# Altium schematic sheet Mesh_Periph.SchDoc(Mesh_Periph)
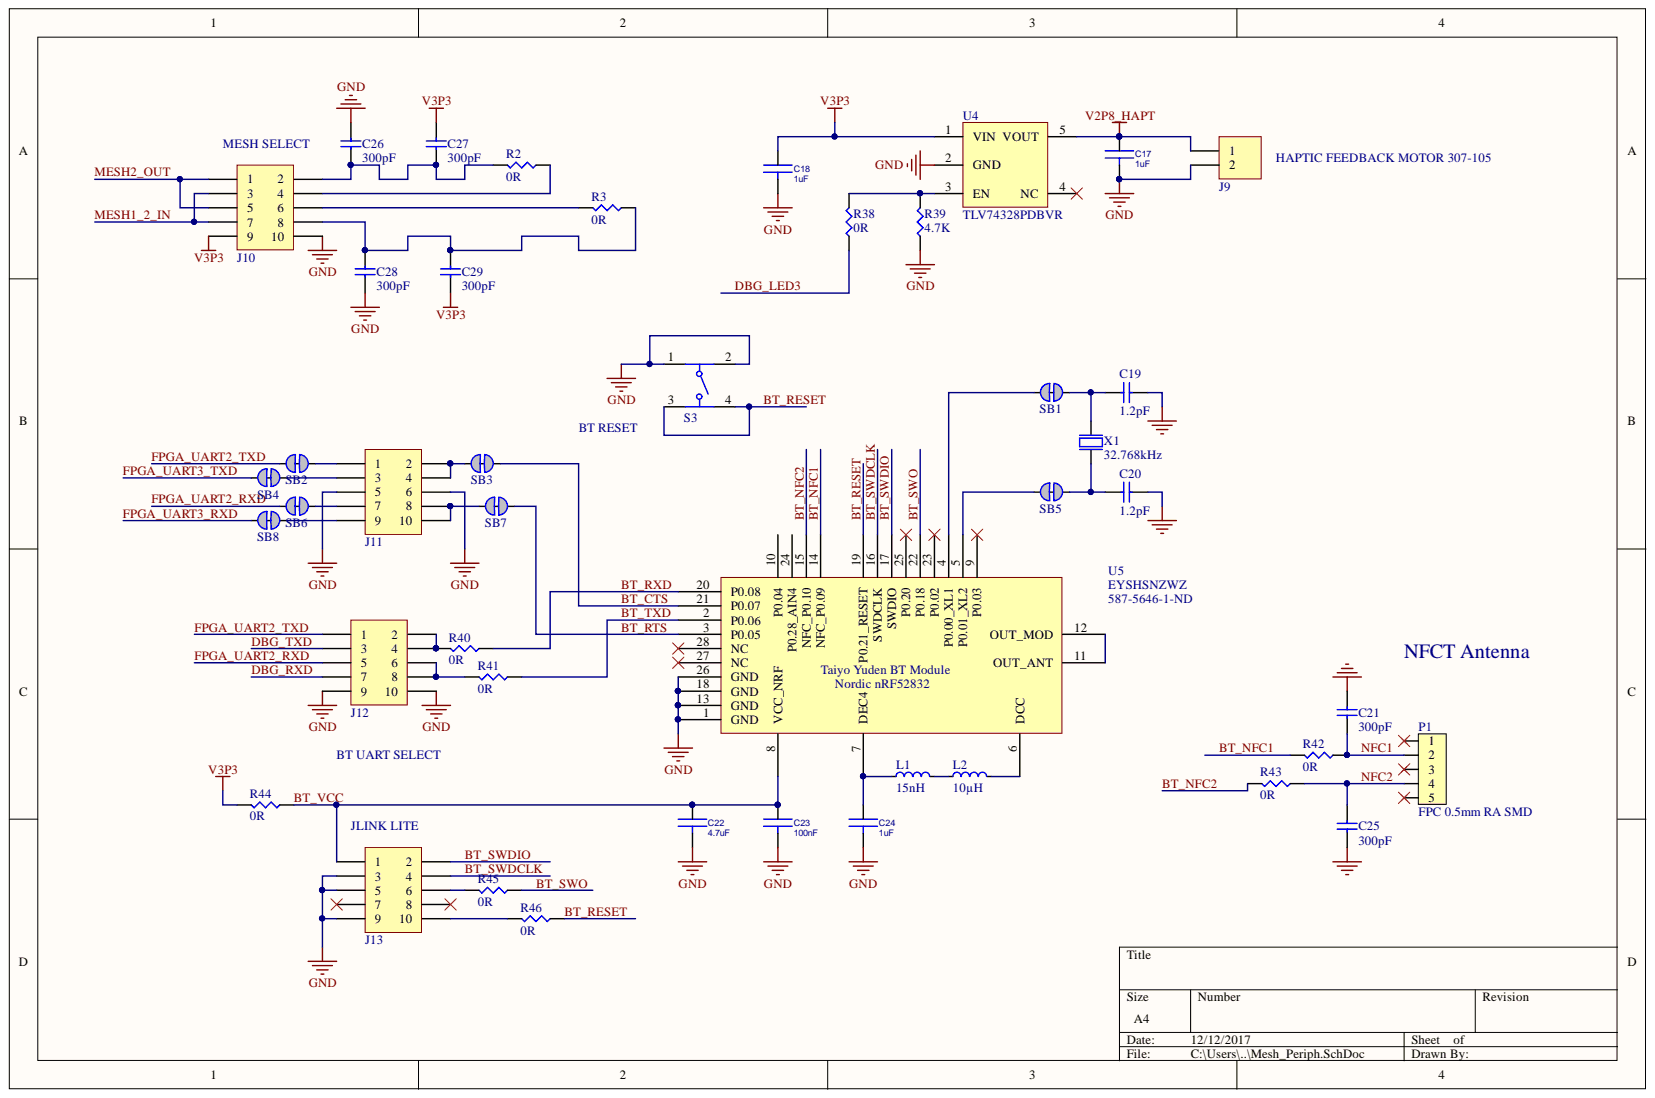
[diagram p0: Mesh_Periph.SchDoc]

## Parts
C17  1uF  [0603C]
C18  1uF  [0603C]
C19  1.2pF  [CAPC1005X04L]
C20  1.2pF  [CAPC1005X04L]
C21  300pF  [CAPC1608X06L]
C22  4.7uF  [0603C]
C23  100nF  [0603C]
C24  1uF  [0603C]
C25  300pF  [CAPC1608X06L]
C26  300pF  [CAPC1608X06L]
C27  300pF  [CAPC1608X06L]
C28  300pF  [CAPC1608X06L]
C29  300pF  [CAPC1608X06L]
J9  61300211121  [61300211121]  (value not drawn)
J10  61301021121  [61301021121]  (value not drawn)
J11  61301021121  [61301021121]  (value not drawn)
J12  61301021121  [61301021121]  (value not drawn)
J13  62201021121  [62201021121]  (value not drawn)
L1  15nH  [INDC1005X04L]
L2  10µH  [INDC1608X06L]
P1  FPC 0.5mm RA SMD  [FPC_05P-0.5mm-RA-SMD]
R2  0R  [R0603]
R3  0R  [R0603]
R38  0R  [R0603]
R39  4.7K  [R0603]
R40  0R  [R0603]
R41  0R  [R0603]
R42  0R  [R0603]
R43  0R  [R0603]
R44  0R  [R0603]
R45  0R  [R0603]
R46  0R  [R0603]
S3  430773034825  [4307x30348xx_6x6x3.4]  (value not drawn)
SB1  Solderbridge  [Solderbridge_Shorted]  (value not drawn)
SB2  Solderbridge  [Solderbridge_Shorted]  (value not drawn)
SB3  Solderbridge  [Solderbridge_Shorted]  (value not drawn)
SB4  Solderbridge  [Solderbridge_Shorted]  (value not drawn)
SB5  Solderbridge  [Solderbridge_Shorted]  (value not drawn)
SB6  Solderbridge  [Solderbridge_Shorted]  (value not drawn)
SB7  Solderbridge  [Solderbridge_Shorted]  (value not drawn)
SB8  Solderbridge  [Solderbridge_Shorted]  (value not drawn)
U4  TLV74328PDBVR  [SOT23-5]
U5  EYSHSNZWZ  Supplier Part Number 1: 587-5646-1-ND  [EYSHSNZWZ]
X1  32.768kHz  [XTAL_3215]

## Nets
GND: C17.2 C18.2 C19.1 C20.1 C21.1 C22.2 C23.2 C24.2 C25.1 C26.2 C28.1 J9.2 J10.10 J11.5 J11.6 J12.9 J12.10 J13.3 J13.5 J13.9 R39.1 S3.1 S3.2 U4.2(GND) U5.1(GND) U5.13(GND) U5.18(GND) U5.26(GND)
V2P8_HAPT: C17.1 J9.1 U4.5(VOUT)
V3P3: C18.1 C27.2 C29.1 J10.9 R44.2 U4.1(VIN)
BT_CTS: SB3.1 U5.21(P0.07)
BT_NFC1: R42.2 U5.14(NFC_P0.09)
BT_NFC2: R43.2 U5.15(NFC_P0.10)
BT_RESET: R46.1 S3.3 S3.4 U5.19(P0.21_RESET)
BT_RTS: SB7.1 U5.3(P0.05)
BT_RXD: R40.1 U5.20(P0.08)
BT_SWDCLK: J13.4 U5.16(SWDCLK)
BT_SWDIO: J13.2 U5.17(SWDIO)
BT_SWO: R45.1 U5.22(P0.18)
BT_TXD: R41.1 U5.2(P0.06)
BT_VCC: C22.1 C23.1 J13.1 R44.1 U5.8(VCC_NRF)
DBG_LED3: R38.1
DBG_RXD: J12.7
DBG_TXD: J12.3
FPGA_UART2_RXD: J12.5 SB6.2
FPGA_UART2_TXD: J12.1 SB2.2
FPGA_UART3_RXD: SB8.2
FPGA_UART3_TXD: SB4.2
MESH1_2_IN: J10.3 J10.7
MESH2_OUT: J10.1 J10.5
NFC1: C21.2 P1.2 R42.1
NFC2: C25.2 P1.4 R43.1
NetC19_2: C19.2 SB1.1 X1.1
NetC20_2: C20.2 SB5.1 X1.2
NetC24_1: C24.1 L1.1 U5.7(DEC4)
NetC26_1: C26.1 C27.1 J10.2 R2.2
NetC28_2: C28.2 C29.2 J10.8 R3.1
NetJ10_4: J10.4 R2.1
NetJ10_6: J10.6 R3.2
NetJ11_1: J11.1 SB2.1
NetJ11_2: J11.2 J11.4 SB3.2
NetJ11_3: J11.3 SB4.1
NetJ11_7: J11.7 SB6.1
NetJ11_8: J11.8 J11.10 SB7.2
NetJ11_9: J11.9 SB8.1
NetJ12_2: J12.2 J12.4 R40.2
NetJ12_6: J12.6 J12.8 R41.2
NetJ13_6: J13.6 R45.2
NetJ13_10: J13.10 R46.2
NetL1_2: L1.2 L2.1
NetL2_2: L2.2 U5.6(DCC)
NetR38_2: R38.2 R39.2 U4.3(EN)
NetSB1_2: SB1.2 U5.4(P0.00_XL1)
NetSB5_2: SB5.2 U5.5(P0.01_XL2)
NetU5_11: U5.11(OUT_ANT) U5.12(OUT_MOD)

Not connected: J13 7 8; P1 1 3 5; U4 4(NC); U5 9(P0.03) 10(P0.04) 23(P0.02) 24(P0.28_AIN4) 25(P0.20) 27(NC) 28(NC)

## Library data of the parts
C17 C18 C22 C24 = 1uF/0603/16V: Description 1: CAP TANT 1UF 16V 10% 0603; Supplier Part Number 1: 478-4184-2-ND; Supplier 1: Digi-Key; Library Name: Control_Board.SchLib; Component Kind: Standard
C19 C20 = CAP_0402: Capacitor, NP0, ±0.1pF; Part Number: C0402-1.2p; Note: 50V; Artikkelnr Simpro: N.A.; Artikkelnr Noca: 20029157; Value: 1.2pF; Published: 2009-05-08; Pin Count: 2; Library Name: pca10040.IntLib; LatestRevisionDate: 2011-05-11; Footprint Doc: 0402; Component Kind: Standard; Publisher: Nordic Semiconductor
C21 C25-C29 = CAP_0603: Capacitor, X5R, ±10%; Part Number: C0603-4µ7; Value: 4.7µF; Note: 6.3V; Artikkelnr Simpro: N.A.; Artikkelnr Noca: 20069060; Published: 2009-05-08; Pin Count: 2; Library Name: pca10040.IntLib; LatestRevisionDate: 2009-11-23; Footprint Doc: 0603; Component Kind: Standard; Publisher: Nordic Semiconductor
C23 = 100nF/0603: Description 1: CAP CER 0.1UF 25V 10% X7R 0603; Supplier Part Number 1: 311-1341-6-ND; Supplier 1: Digi-Key; Library Name: Control_Board.SchLib; Component Kind: Standard
J9 = 61300211121: WR-PHD 2.54 mm THT Pin Header, 2p; Working Voltage: 250 VAC; Withstanding Voltage: 500 VAC; Rated Current: 3 A; Pitch: 2.54 mm; Pin Number: 2; Published Date: Aug 2017; Part Number: 61300211121; Library Name: Altium_WR-PHD_PIN_HEADER_2_54 (rev17a).IntLib; ComponentLink2URL: http://katalog.we-online.de/em/datasheet/6130xx11121.pdf; ComponentLink2Description: Datasheet; ComponentLink1URL: http://www.we-online.de; ComponentLink1Description: Manufacturer; Component Kind: Standard; Publisher: Wurth Elektronik
J10-J12 = 61301021121: WR-PHD 2.54 mm THT Dual Pin Header, 10p; Working Voltage: 250 VAC; Withstanding Voltage: 500 VAC; Rated Current: 3 A; Pitch: 2.54 mm; Pin Number: 10; Published Date: Aug 2017; Part Number: 61301021121; Library Name: Altium_WR-PHD_PIN_HEADER_2_54 (rev17a).IntLib; ComponentLink2URL: http://katalog.we-online.de/em/datasheet/6130xx21121.pdf; ComponentLink2Description: Datasheet; ComponentLink1URL: http://www.we-online.de; ComponentLink1Description: Manufacturer; Component Kind: Standard; Publisher: Wurth Elektronik
J13 = 62201021121: WR-PHD 1.27 mm THT Dual Pin Header, 10p; Working Voltage: 100 VAC; Withstanding Voltage: 500 VAC; Rated Current: 1 A; Pitch: 1.27 mm; Pin Number: 10; Published Date: Aug 2017; Part Number: 62201021121; Library Name: 6220xx21121 (rev17b).IntLib; ComponentLink2URL: http://katalog.we-online.de/em/datasheet/62201021121.pdf; ComponentLink2Description: Datasheet; ComponentLink1URL: http://www.we-online.de; ComponentLink1Description: Manufacturer; Component Kind: Standard; Publisher: Wurth Elektronik
L1 = L0402-15n: Inductor, 320mA, ±5%; Manufacturer Part Number: HK100515NJ-T; Manufacturer: Taiyo Yuden; 2nd source part number: 658-1328; Note: High frequency chip inductor ±10%; Artikkelnr Simpro: N.A.; Artikkelnr Noca: 33029323; Value: 15nH; Supplier part number: 587-1521-1-ND; Supplier: Digi-Key; Published: 2010-07-12; Pin Count: 2; Part Number: L0402-15n; Library Name: pca10040.IntLib; LatestRevisionDate: 2012-09-19; Footprint Doc: 0402; Datasheet: http://www.yuden.co.jp/productdata/catalog/en/mlci04_e.pdf; Component Kind: Standard; 2nd source Supplier: Farnell; Publisher: Nordic Semiconductor
L2 = L0603-10µ: Inductor, 80mA, ±10%; Manufacturer Part Number: LBMF1608T100K; Manufacturer: Taiyo Yuden; 2nd source part number: 178-6105; Note: LBMF1608T100K 10µH 80mA 0603; Artikkelnr Simpro: N.A.; Artikkelnr Noca: 33029314; Value: 10µH; Supplier part number: 587-1714-1-ND; Supplier: Digi-Key; Published: 2010-02-24; Pin Count: 2; Part Number: L0603-10µ; Library Name: pca10040.IntLib; LatestRevisionDate: 2012-09-19; Footprint Doc: 0603; Datasheet: http://www.yuden.co.jp/ut/product/pdf/elb2_e.pdf; Component Kind: Standard; 2nd source Supplier: Farnell; Publisher: Nordic Semiconductor
P1 = FPC_05P-0.5mm: 0.5mm FPC, 5P,Right Angle SMT,Double Contact,H=1.2mm,White, Tin plated; Manufacturer Part Number: 5141-05RZDNWR01; Manufacturer: W-CON; 2nd source part number: WM9836CT-ND; Artikkelnr Simpro: N.A.; Artikkelnr Noca: 55010020; Value: FPC 0.5mm RA SMD; Supplier part number: 5141-05RZDNWR01; Supplier: Cabcon; Published: 2015-06-22; Pin Count: 7; Part Number: FPC_05P-0.5mm-RA-SMD; Library Name: pca10040.IntLib; LatestRevisionDate: 2016-04-21; Footprint Doc: FPC_05P-0.5mm-RA-SMD; Datasheet: \\hizz\pro\app9717\Datasheet\connectores\W-CON\5141-XXRZDNXX0X.pdf; Component Kind: Standard; 2nd source Supplier: Digi-Key; Publisher: Nordic Semiconductor
R2 R3 R38-R46 = 1K/1%/0603: Description 1: Thick Film Resistors - SMD 1K OHM 1%; Supplier Part Number 1: 311-1.00KHRCT-ND; Supplier 1: Digi-Key; Library Name: Control_Board.SchLib; Component Kind: Standard
S3 = 430773034825: WS-TASV 6x6mm J-Hook Hermetic Tact Switch, SMD version; Operation Force: 250g; Size: 6x6x3.4 mm; Published Date: June 2017; Part Number: 430773034825; Life Cycle: 500000 cycles; Library Name: Altium_WS-TASV (rev17a).IntLib; ComponentLink2URL: http://katalog.we-online.de/em/datasheet/430773034825.pdf; ComponentLink2Description: Datasheet; ComponentLink1URL: http://www.we-online.de; ComponentLink1Description: Manufacturer; Component Kind: Standard; Publisher: Wurth Elektronik
SB1-SB8 = SOLDERBRIDGE_SHORTED: Shorted Solderbridge; Part Number: Solderbridge Shorted; Artikkelnr Simpro: N.A.; Value: Solderbridge; Published: 2012-08-07; Pin Count: 2; Library Name: pca10040.IntLib; LatestRevisionDate: 2012-08-07; Footprint Doc: Solderbridge_Shorted; Component Kind: Net Tie; Artikkelnr Noca: N.A.; Publisher: Nordic Semiconductor
U4 = RT9198-28PBR: Descritpion 1: 300mA, Low Noise, Ultra-Fast CMOS LDO REG, 2.8V; Supplier Part Number 1: RT9198-28PBR; Supplier 1: Richtek; Component Kind: Standard; Library Name: AMOLED.SchLib
X1 = XTAL_FC-135 32.768k: EPSON TOYOCOM - FC-135 32.768KHZ ±20PPM,9.0PF - CRYSTAL, SM, WATCH; 2nd source part number: 127-8037; Note: XTAL SMD 32.768kHz, 3215; Artikkelnr Simpro: N.A.; Artikkelnr Noca: 22019091; Value: 32.768kHz; Supplier part number: FC-135 32.7680KA-AC-ND; Supplier: Digi-Key; Published: 2010-10-18; Pin Count: 2; Part Number: XTAL_FC-135 32.768k; Manufacturer Part Number: FC-135 32.768KHZ ±20PPM,9.0PF; Manufacturer: Epson Toyocom; Library Name: pca10040.IntLib; LatestRevisionDate: 2014-03-27; Footprint Doc: XTAL_3215; Datasheet: http://www.farnell.com/datasheets/57480.pdf; Component Kind: Standard; 2nd source Supplier: Farnell; Publisher: Nordic Semiconductor
U5 = EYSHSNZWZ: Supplier 1: Digi-Key; Description: EYSHSNZWZ; Component Kind: Standard; Library Name: PSD_ECB.SchLib
